# Altium schematic sheet Power.SchDoc(Power)
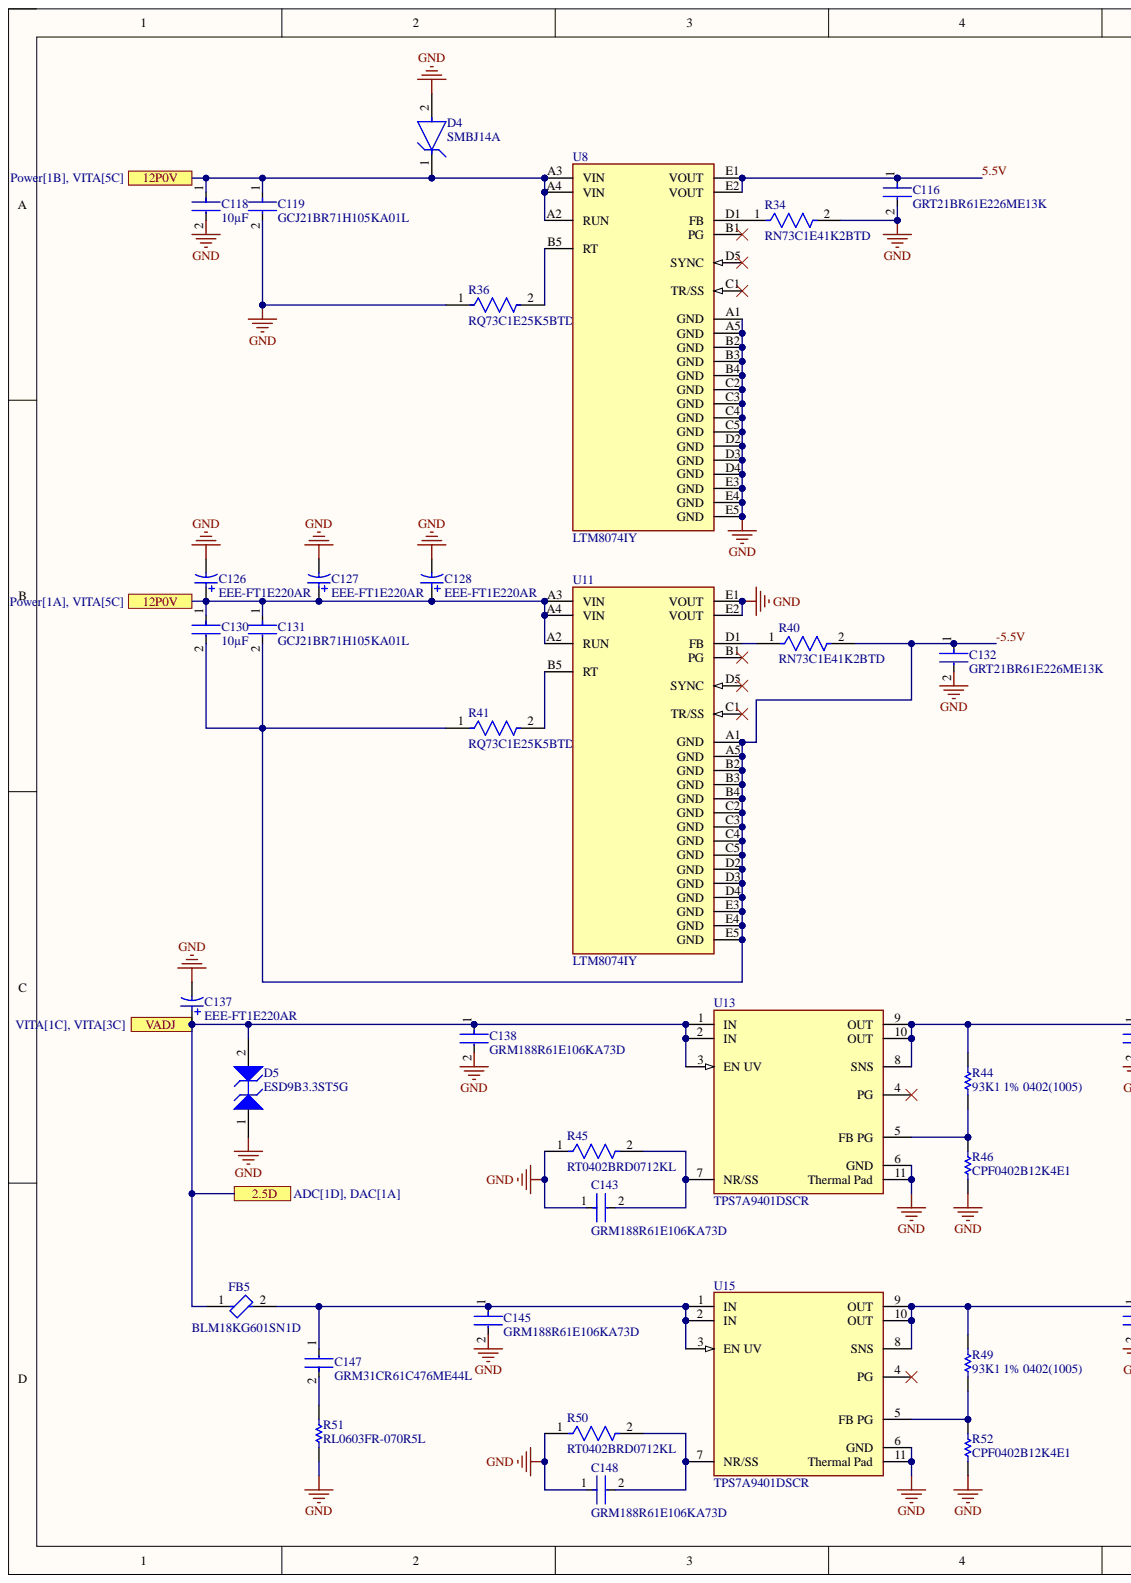
[diagram p0: Power.SchDoc, left]
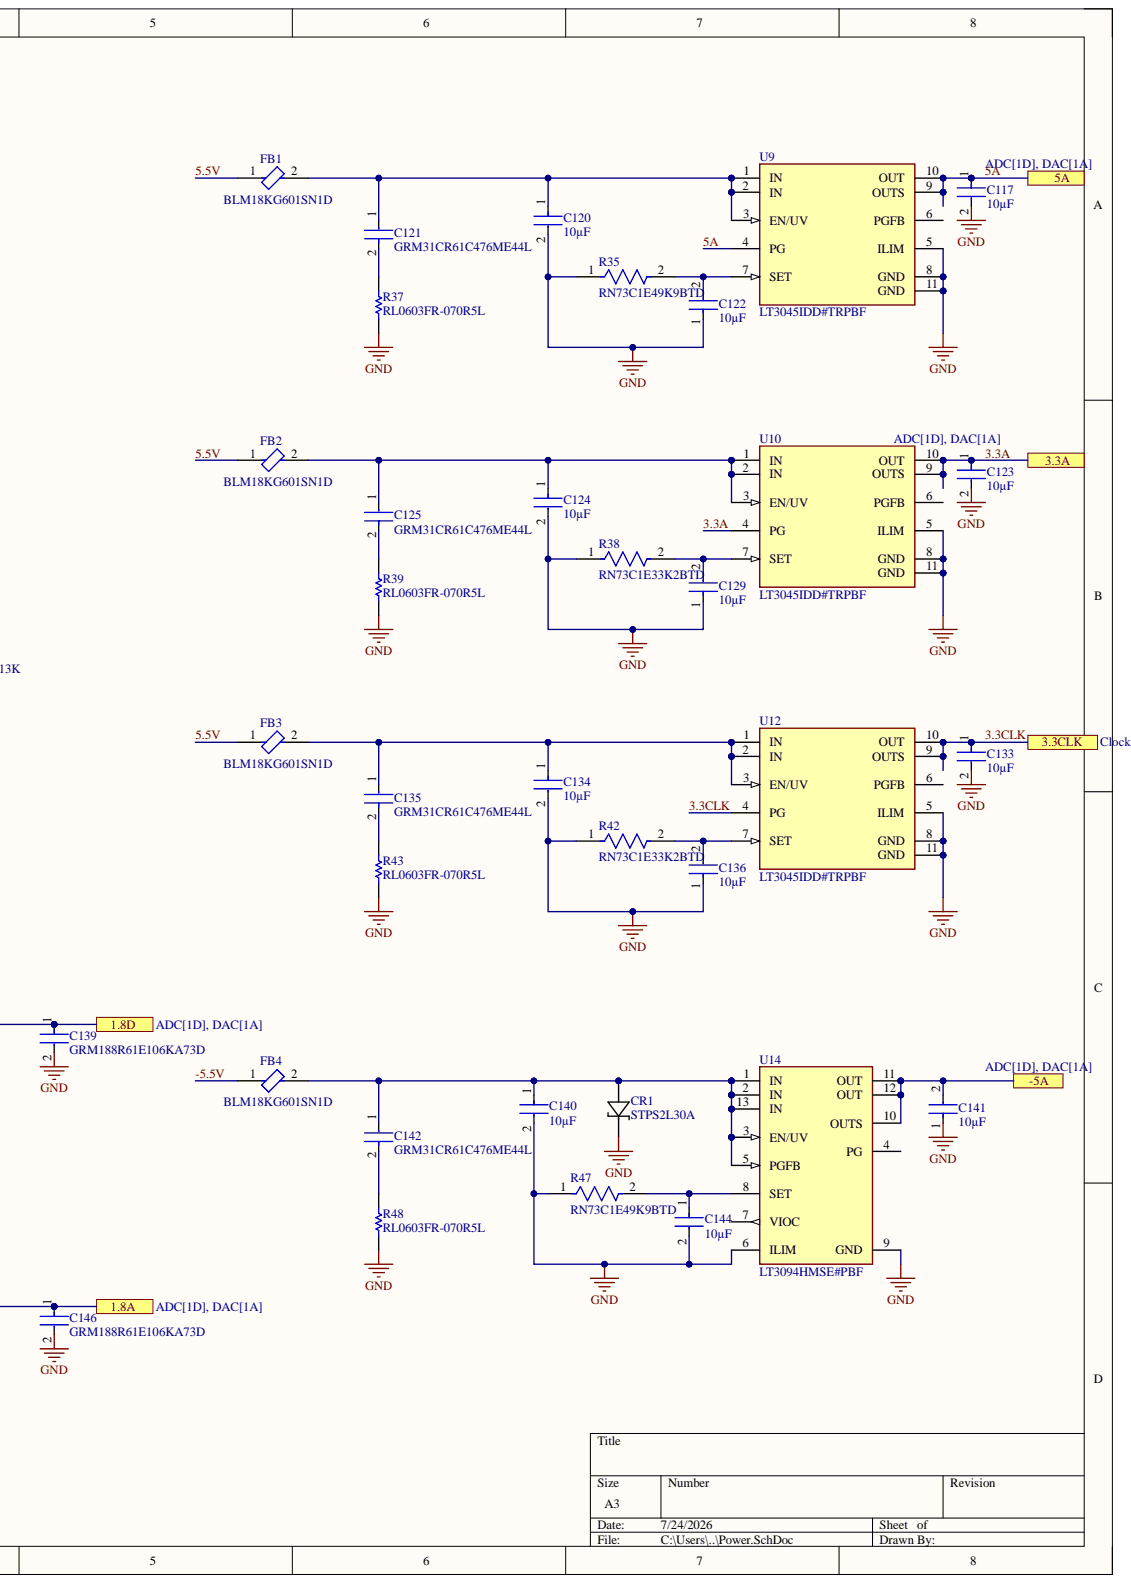
[diagram p1: Power.SchDoc, right]

## Parts
C116  GRT21BR61E226ME13K  [FP-GRT21B-0_2-IPC_C]
C117  GRM188R61E106KA73D  value 10µF  [FP-GRM188-0_2-IPC_C]
C118  GRM188R61E106KA73D  value 10µF  [FP-GRM188-0_2-IPC_C]
C119  GCJ21BR71H105KA01L  [FP-GCJ21B-0_3-IPC_C]
C120  GRM188R61E106KA73D  value 10µF  [FP-GRM188-0_2-IPC_C]
C121  GRM31CR61C476ME44L  [FP-GRM31C-0_3-e0_3_0_8-IPC_C]
C122  GRM188R61E106KA73D  value 10µF  [FP-GRM188-0_2-IPC_C]
C123  GRM188R61E106KA73D  value 10µF  [FP-GRM188-0_2-IPC_C]
C124  GRM188R61E106KA73D  value 10µF  [FP-GRM188-0_2-IPC_C]
C125  GRM31CR61C476ME44L  [FP-GRM31C-0_3-e0_3_0_8-IPC_C]
C126  EEE-FT1E220AR  [PNSC-FT-V-43x43-2_V]
C127  EEE-FT1E220AR  [PNSC-FT-V-43x43-2_V]
C128  EEE-FT1E220AR  [PNSC-FT-V-43x43-2_V]
C129  GRM188R61E106KA73D  value 10µF  [FP-GRM188-0_2-IPC_C]
C130  GRM188R61E106KA73D  value 10µF  [FP-GRM188-0_2-IPC_C]
C131  GCJ21BR71H105KA01L  [FP-GCJ21B-0_3-IPC_C]
C132  GRT21BR61E226ME13K  [FP-GRT21B-0_2-IPC_C]
C133  GRM188R61E106KA73D  value 10µF  [FP-GRM188-0_2-IPC_C]
C134  GRM188R61E106KA73D  value 10µF  [FP-GRM188-0_2-IPC_C]
C135  GRM31CR61C476ME44L  [FP-GRM31C-0_3-e0_3_0_8-IPC_C]
C136  GRM188R61E106KA73D  value 10µF  [FP-GRM188-0_2-IPC_C]
C137  EEE-FT1E220AR  [PNSC-FT-V-43x43-2_V]
C138  GRM188R61E106KA73D  [FP-GRM188-0_2-IPC_C]
C139  GRM188R61E106KA73D  [FP-GRM188-0_2-IPC_C]
C140  GRM188R61E106KA73D  value 10µF  [FP-GRM188-0_2-IPC_C]
C141  GRM188R61E106KA73D  value 10µF  [FP-GRM188-0_2-IPC_C]
C142  GRM31CR61C476ME44L  [FP-GRM31C-0_3-e0_3_0_8-IPC_C]
C143  GRM188R61E106KA73D  [FP-GRM188-0_2-IPC_C]
C144  GRM188R61E106KA73D  value 10µF  [FP-GRM188-0_2-IPC_C]
C145  GRM188R61E106KA73D  [FP-GRM188-0_2-IPC_C]
C146  GRM188R61E106KA73D  [FP-GRM188-0_2-IPC_C]
C147  GRM31CR61C476ME44L  [FP-GRM31C-0_3-e0_3_0_8-IPC_C]
C148  GRM188R61E106KA73D  [FP-GRM188-0_2-IPC_C]
CR1  STPS2L30A  [SMA_STM]
D4  SMBJ14A  [FP-SMBJ-MFG]
D5  ESD9B3.3ST5G  [ONSC-SOD-923-2-514AB-01_V]
FB1  BLM18KG601SN1D  [FP-BLM18-0_15-t0_8-MFG]
FB2  BLM18KG601SN1D  [FP-BLM18-0_15-t0_8-MFG]
FB3  BLM18KG601SN1D  [FP-BLM18-0_15-t0_8-MFG]
FB4  BLM18KG601SN1D  [FP-BLM18-0_15-t0_8-MFG]
FB5  BLM18KG601SN1D  [FP-BLM18-0_15-t0_8-MFG]
R34  RN73C1E41K2BTD  [FP-RN731E-IPC_C]
R35  RN73C1E49K9BTD  [FP-RN731E-IPC_C]
R36  RQ73C1E25K5BTD  [FP-RQ731E-IPC_C]
R37  RL0603FR-070R5L  [RESC1608X55X25LL10T15]
R38  RN73C1E33K2BTD  [FP-RN731E-IPC_C]
R39  RL0603FR-070R5L  [RESC1608X55X25LL10T15]
R40  RN73C1E41K2BTD  [FP-RN731E-IPC_C]
R41  RQ73C1E25K5BTD  [FP-RQ731E-IPC_C]
R42  RN73C1E33K2BTD  [FP-RN731E-IPC_C]
R43  RL0603FR-070R5L  [RESC1608X55X25LL10T15]
R44  93K1 1% 0402(1005)  [RESC0402(1005)_L]
R45  RT0402BRD0712KL  [FP-RT0402-IPC_C]
R46  CPF0402B12K4E1  [RESC1005X35X20LL05T10]
R47  RN73C1E49K9BTD  [FP-RN731E-IPC_C]
R48  RL0603FR-070R5L  [RESC1608X55X25LL10T15]
R49  93K1 1% 0402(1005)  [RESC0402(1005)_L]
R50  RT0402BRD0712KL  [FP-RT0402-IPC_C]
R51  RL0603FR-070R5L  [RESC1608X55X25LL10T15]
R52  CPF0402B12K4E1  [RESC1005X35X20LL05T10]
U8  LTM8074IY  [FP-BGA-25-05-08-1566-MFG]
U9  LT3045IDD#TRPBF  [FP-DD-10-05-08-1699-MFG]
U10  LT3045IDD#TRPBF  [FP-DD-10-05-08-1699-MFG]
U11  LTM8074IY  [FP-BGA-25-05-08-1566-MFG]
U12  LT3045IDD#TRPBF  [FP-DD-10-05-08-1699-MFG]
U13  TPS7A9401DSCR  [FP-DSC0010J-MFG]
U14  LT3094HMSE#PBF  [FP-MSE-12-05-08-1666-MFG]
U15  TPS7A9401DSCR  [FP-DSC0010J-MFG]

## Ports
1.8A, 1.8D, 2.5D, 3.3A, 3.3CLK, 5A, 12P0V, -5A, VADJ

## Nets
GND: C116.2 C117.2 C118.2 C119.2 C120.2 C122.1 C123.2 C124.2 C126.2 C127.2 C128.2 C129.1 C132.2 C133.2 C134.2 C136.1 C137.2 C138.2 C139.2 C140.2 C141.1 C143.1 C144.2 C145.2 C146.2 C148.1 CR1.2 D4.2 D5.1 R34.2 R35.1 R36.1 R37.1 R38.1 R39.1 R42.1 R43.1 R45.1 R46.1 R47.1 R48.1 R50.1 R51.1 R52.1 U8.A1(GND) U8.A5(GND) U8.B2(GND) U8.B3(GND) U8.B4(GND) U8.C2(GND) U8.C3(GND) U8.C4(GND) U8.C5(GND) U8.D2(GND) U8.D3(GND) U8.D4(GND) U8.E3(GND) U8.E4(GND) U8.E5(GND) U9.5(ILIM) U9.8(GND) U9.11(GND) U10.5(ILIM) U10.8(GND) U10.11(GND) U11.E1(VOUT) U11.E2(VOUT) U12.5(ILIM) U12.8(GND) U12.11(GND) U13.6(GND) U13.11(Thermal_Pad) U14.6(ILIM) U14.9(GND) U15.6(GND) U15.11(Thermal_Pad)
1.8A: C146.1 R49.2 U15.8(SNS) U15.9(OUT) U15.10(OUT) ; port 1.8A
1.8D: C139.1 R44.2 U13.8(SNS) U13.9(OUT) U13.10(OUT) ; port 1.8D
2.5D: C137.1 C138.1 D5.2 FB5.1 U13.1(IN) U13.2(IN) U13.3(EN_UV) ; port 2.5D, port VADJ
3.3A: C123.1 U10.4(PG) U10.9(OUTS) U10.10(OUT) ; port 3.3A
3.3CLK: C133.1 U12.4(PG) U12.9(OUTS) U12.10(OUT) ; port 3.3CLK
5.5V: C116.1 FB1.1 FB2.1 FB3.1 U8.E1(VOUT) U8.E2(VOUT)
5A: C117.1 U9.4(PG) U9.9(OUTS) U9.10(OUT) ; port 5A
12P0V: C118.1 C119.1 C126.1 C127.1 C128.1 C130.1 C131.1 D4.1 U8.A2(RUN) U8.A3(VIN) U8.A4(VIN) U11.A2(RUN) U11.A3(VIN) U11.A4(VIN) ; port 12P0V
-5.5V: C130.2 C131.2 C132.1 FB4.1 R40.2 R41.1 U11.A1(GND) U11.A5(GND) U11.B2(GND) U11.B3(GND) U11.B4(GND) U11.C2(GND) U11.C3(GND) U11.C4(GND) U11.C5(GND) U11.D2(GND) U11.D3(GND) U11.D4(GND) U11.E3(GND) U11.E4(GND) U11.E5(GND)
-5A: C141.2 U14.10(OUTS) U14.11(OUT) U14.12(OUT) ; port -5A
NetC120_1: C120.1 C121.1 FB1.2 U9.1(IN) U9.2(IN) U9.3(EN/UV)
NetC121_2: C121.2 R37.2
NetC122_2: C122.2 R35.2 U9.7(SET)
NetC124_1: C124.1 C125.1 FB2.2 U10.1(IN) U10.2(IN) U10.3(EN/UV)
NetC125_2: C125.2 R39.2
NetC129_2: C129.2 R38.2 U10.7(SET)
NetC134_1: C134.1 C135.1 FB3.2 U12.1(IN) U12.2(IN) U12.3(EN/UV)
NetC135_2: C135.2 R43.2
NetC136_2: C136.2 R42.2 U12.7(SET)
NetC140_1: C140.1 C142.1 CR1.1 FB4.2 U14.1(IN) U14.2(IN) U14.3(EN/UV) U14.5(PGFB) U14.13(IN)
NetC142_2: C142.2 R48.2
NetC143_2: C143.2 R45.2 U13.7(NR/SS)
NetC144_1: C144.1 R47.2 U14.8(SET)
NetC145_1: C145.1 C147.1 FB5.2 U15.1(IN) U15.2(IN) U15.3(EN_UV)
NetC147_2: C147.2 R51.2
NetC148_2: C148.2 R50.2 U15.7(NR/SS)
NetR34_1: R34.1 U8.D1(FB)
NetR36_2: R36.2 U8.B5(RT)
NetR40_1: R40.1 U11.D1(FB)
NetR41_2: R41.2 U11.B5(RT)
NetR44_1: R44.1 R46.2 U13.5(FB_PG)
NetR49_1: R49.1 R52.2 U15.5(FB_PG)

Not connected: U8 B1(PG) C1(TR/SS) D5(SYNC); U9 6(PGFB); U10 6(PGFB); U11 B1(PG) C1(TR/SS) D5(SYNC); U12 6(PGFB); U13 4(PG); U14 4(PG) 7(VIOC); U15 4(PG)

## Library data of the parts
C116 C132 = CMP-06035-023845-1: Multi-Layer Ceramic Capacitor 22uF 25V X5R ±20% 0805 Emboss T/R; Component Kind: Standard; Library Name: Altium Content Vault
C117 C118 C120 C122-C124 C129 C130 C133 C134 C136 C140 C141 C144 = CMP-001-00086-1: 25V 10uF X5R ±10% 0603 Multilayer Ceramic Capacitors MLCC - SMD/SMT ROHS; Case/Package: 0603; Component Kind: Standard; Max Operating Temperature: 85°C; Min Operating Temperature: -55°C; Mounting Technology: Surface Mount; Tolerance: 10%; Voltage Rating: 25V; Library Name: Alex Clunan
C119 C131 = CMP-06035-043290-1: Multilayer Ceramic Capacitors MLCC - SMD/SMT 0805 1uF 10%, 50VDC,  X7R 15%; Voltage Rating: 50V; Capacitance: 1uF; Case Code (Imperial): 0805; Case Code (Metric): 2012; Case/Package: 0805; Component Kind: Standard; Dielectric: X7R; Features: Soft Termination; Height: 1.25mm; Lead Free: Lead Free; Length: 0.079inch; Library Name: Altium Content Vault; Max Operating Temperature: 125°C; Min Operating Temperature: -55°C; Mount: Surface Mount; Package Quantity: 3000; Packaging: Tape and Reel; Ratings: AEC-Q200; Termination: SMD/SMT; Thickness: 0.057inch; Tolerance: 10%; Voltage Rating (DC): 50V; Weight: 0.000335oz; Width: 0.049inch
C121 C125 C135 C142 C147 = CMP-06035-011430-1: Chip Multilayer Ceramic Capacitors for General Purpose, 1206, 47uF, X5R, 15%, 20%, 16V; Capacitance: 47uF; Component Kind: Standard; Dielectric: X5R; Length: 3.2mm; Library Name: Altium Content Vault; Thickness: 1.6mm; Tolerance: 20%; Voltage Rating (DC): 16V; Width: 1.6mm
C126-C128 C137 = CMP-0744-00004-1: Aluminum Electrolytic Capacitor, 22 uF +/- 20%, 25 V, -55 to 105 degC, 2-Pin 4.3 x 4.3 x 6.1 mm SMD, RoHS, Tape and Reel; Manufacturer: Panasonic; Capacitance: 22 uF; Capacitance Tolerance: +/- 20%; Rated Voltage: 25 V; Ripple Current: 160 mA; Component Kind: Standard; ComponentLink1Description: Manufacturer URL; ComponentLink1URL: http://www.panasonic.com/industrial/electronic-components/; ComponentLink2Description: Datasheet; ComponentLink2URL: http://industrial.panasonic.com/www-data/pdf/ABA0000/ABA0000CE135.pdf; ComponentLink3Description: Package Specification; ComponentLink3URL: http://industrial.panasonic.com/www-data/pdf/ABA0000/ABA0000PE248.pdf; DatasheetVersion: Mar-14; Library Name: Altium Content Vault; Mounting Technology: Surface Mount; PackageDescription: 2-Pin SMD, Body 4.3 x 4.3 mm, Height 6.1 mm; PackageReference: FT-V-43x43-2; PackageVersion: Feb-14; Packing: Tape and Reel; PartNumber: EEE-FT1E220AR; RoHS: TRUE; Temp. Range: -55 to 105 degC
C138 C139 C143 C145 C146 C148 = CMP-06035-045268-1: Chip Multilayer Ceramic Capacitors for General Purpose, 0603, 10uF, X5R, 15%, 10%, 25V; Case/Package: 0603; Component Kind: Standard; Library Name: Altium Content Vault
CR1 = STPS2L30A: 30 V, 2 A Low Drop Power Schottky Rectifier; Manufacturer_Name: STMicroelectronics; Component Kind: Standard; Library Name: STPS2L30A.IntLib; Manufacturer_Part_Number: STPS2L30A; Copyright: Copyright (C) 2025 Ultra Librarian. All rights reserved.
D4 = CMP-15642-000001-1: TVS DIODE 14V 23.2V DO214AA; Manufacturer: Taiwan Semiconductor Corporation; Breakdown Voltage: 15.6V; Direction: Unidirectional; Max Junction Temp: +150°C; Number Of Channels: 1; Peak Pulse Current: 27A; Peak Pulse Power: 600W; Standoff Height: 0.05mm; Automotive: No; Category: Diode; Clamping Voltage: 23.2V; Component Kind: Standard; ComponentLink2Description: Datasheet; ComponentLink2URL: https://www.taiwansemi.com/products/datasheet/SMBJ%20SERIES_P1812.pdf; Device Class L1: Circuit Protection; Device Class L2: TVS Diodes; Device Class L3: unset; Digikey Description: TVS DIODE 14V 23.2V DO214AA; Height: 2.65mm; Lead Free: Yes; Library Name: Altium Content Vault; Manufacturer Part Number: SMBJ14A; Mouser Description: TVS Diodes - Transient Voltage Suppressors 14V 600W 5% Uni; Octopart: https://octopart.com/smbj14a-taiwan+semiconductor-13998009; Package: SMBJ; Reverse Standoff Voltage: 14V; RoHS: TRUE; Temperature: -55°C to +150°C; Temperature Range High: +150°C; Temperature Range Low: -55°C
D5 = CMP-1063-00120-1: Transient Voltage Suppressor, Micro-Packaged Diode for ESD Protection, 2-Pin SOD-923, Pb-Free, Tape and Reel; Manufacturer: On Semiconductor; Direction: Bidirectional; IR Max (uA): 1; V(BR) Min (V): 5; VRWM Max (V): 3.3; Component Kind: Standard; ComponentLink1Description: Manufacturer URL; ComponentLink1URL: http://www.onsemi.com/; ComponentLink2Description: Datasheet; ComponentLink2URL: http://www.onsemi.cn/pub_link/Collateral/ESD9B-D.PDF; ComponentLink3Description: Package Specification; ComponentLink3URL: http://www.onsemi.com/pub_link/Collateral/514AB.PDF; DatasheetVersion: Rev. 2; Library Name: Altium Content Vault; Mounting Technology: Surface Mount; Number of Lines: 1; PackageDescription: 2-Pin SOD, Body 0.8 x 0.6 mm; PackageReference: SOD-923-2-514AB-01; PackageVersion: Rev. C, 03/2011; Packing: Tape and Reel; PartNumber: ESD9B3.3ST5G; RoHS: Pb-Free
FB1-FB5 = CMP-0686-00581-3: Chip Ferrite Bead, 0603, 600Ω @ 100MHz, 0.15Ω, 25%, 1.3A; DC Resistance (DCR): 150mR; Impedance: 600R; Material: Steel; Number of Circuits: 1; Case Code (Imperial): 0603; Case Code (Metric): 1608; Case/Package: 0603; Component Kind: Standard; Core Material: Ferrite; Current Rating: 1.3A; DC Current: 1.3A; Depth: 0.8mm; Height: 0.8mm; Inductor Type: FERRITE BEAD; Lead Free: Lead Free; Length: 1.6mm; Library Name: Altium Content Vault; Max Current Rating: 1.3A; Max DC Current: 1.3A; Max Operating Temperature: 125°C; Military Standard: Not; Min Operating Temperature: -55°C; Number of Lines: 1; Number of Pins: 2; Package Quantity: 1; Packaging: Tape and Reel; Radiation Hardening: No; REACH SVHC: No SVHC; RoHS Compliant: Yes; Series: BLM18K; Termination: SMD/SMT; Test Frequency: 100MHz; Tolerance: 25%; Weight: 7.1E-05oz; Width: 0.8mm
R34 R40 = CMP-03211-005991-1: Res Thin Film 0402 41.2KΩ 0.1% 1/16W 10ppm/°C Molded SMD; Manufacturer: TE Connectivity; Power Rating: 0.063W; Voltage Rating: 25V; Automotive: No; Category: Res; Component Kind: Standard; ComponentLink2Description: Datasheet; ComponentLink2URL: https://www.te.com/commerce/DocumentDelivery/DDEController?Action=showdoc&DocId=Data+Sheet%7F1773270%7FP%7Fpdf%7FEnglish%7FENG_DS_1773270_P.pdf%7F1-1879132-6; Composition: Thin Film; Device Class L1: Passive Components; Device Class L2: Resistors; Device Class L3: Chip SMD Resistors; Height: 0.35mm; Ipc Land Pattern Name: RESC10050X30; Lead Free: Yes; Library Name: Altium Content Vault; Manufacturer Part Number: RN73C1E41K2BTD; Octopart: https://octopart.com/rn73c1e41k2btd-te+connectivity-76703314; octopart_url: https://octopart.com/rn73c1e41k2btd-te+connectivity-76703314; Package: RN731E; packaging: 5000 Reel; Resistance: 41.2KΩ; RoHS: TRUE; Series: RN73; Temperature: -55°C to +155°C; Temperature Coefficient: 10ppm/°C; Temperature Range High: +155°C; Temperature Range Low: -55°C; Tolerance: 0.1%; Value: 41.2KΩ
R35 R47 = CMP-03211-004568-1: Res Thin Film 0402 49.9KΩ 0.1% 1/16W 10ppm/°C Molded SMD; Manufacturer: TE Connectivity; Power Rating: 0.063W; Voltage Rating: 25V; Automotive: No; Category: Res; Component Kind: Standard; ComponentLink2Description: Datasheet; ComponentLink2URL: https://www.te.com/commerce/DocumentDelivery/DDEController?Action=showdoc&DocId=Data+Sheet%7F1773270%7FP%7Fpdf%7FEnglish%7FENG_DS_1773270_P.pdf%7F1-1879132-6; Composition: Thin Film; Device Class L1: Passive Components; Device Class L2: Resistors; Device Class L3: Chip SMD Resistors; Height: 0.35mm; Ipc Land Pattern Name: RESC10050X30; Lead Free: Yes; Library Name: Altium Content Vault; Manufacturer Part Number: RN73C1E49K9BTD; Octopart: https://octopart.com/rn73c1e49k9btd-te+connectivity-76703322; octopart_url: https://octopart.com/rn73c1e49k9btd-te+connectivity-76703322; Package: RN731E; packaging: 5000 Reel; Resistance: 49.9KΩ; RoHS: TRUE; Series: RN73; Temperature: -55°C to +155°C; Temperature Coefficient: 10ppm/°C; Temperature Range High: +155°C; Temperature Range Low: -55°C; Tolerance: 0.1%; Value: 49.9KΩ
R36 R41 = CMP-03211-003145-1: RQ73 0402 25.5KΩ 0.0625W 10ppm/°C 0.1% 50V; Manufacturer: TE; Automotive Grade: Grade 0; Automotive: Yes; Category: Res; Component Kind: Standard; ComponentLink2Description: Datasheet; ComponentLink2URL: https://www.te.com/commerce/DocumentDelivery/DDEController?Action=showdoc&DocId=Data+Sheet%7F1773270-2%7FA%7Fpdf%7FEnglish%7FENG_DS_1773270-2_A.pdf%7F4-2176362-503; Composition: Thin Film; Device Class L1: Passive Components; Device Class L2: Resistors; Device Class L3: Chip SMD Resistors; Height: 0.4mm; Ipc Land Pattern Name: RESC10050X30; Lead Free: Yes; Library Name: Altium Content Vault; Manufacturer Part Number: RQ73C1E25K5BTD; Octopart: https://octopart.com/rq73c1e25k5btd-te+connectivity-93907600; Package: RQ731E; Power Rating: 0.0625W; Resistance: 25.5KΩ; RoHS: TRUE; Series: RQ73; Temperature: -55°C to +155°C; Temperature Coefficient: 10ppm/°C; Temperature Range High: +155°C; Temperature Range Low: -55°C; Tolerance: 0.1%; Value: 25.5KΩ; Voltage Rating: 50V
R37 R39 R43 R48 R51 = CMP-2000-04335-1: Manufacturer: Yageo; Component Kind: Standard; Library Name: Altium Content Vault; Part Number: RL0603FR-070R5L
R38 R42 = CMP-03211-010041-1: Res Thin Film 0402 33.2KΩ 0.1% 1/16W 10ppm/°C Molded SMD; Manufacturer: TE Connectivity; Power Rating: 0.063W; Voltage Rating: 25V; Automotive: No; Category: Res; Component Kind: Standard; ComponentLink2Description: Datasheet; ComponentLink2URL: https://www.te.com/commerce/DocumentDelivery/DDEController?Action=showdoc&DocId=Data+Sheet%7F1773270%7FP%7Fpdf%7FEnglish%7FENG_DS_1773270_P.pdf%7F1-1879132-6; Composition: Thin Film; Device Class L1: Passive Components; Device Class L2: Resistors; Device Class L3: Chip SMD Resistors; Height: 0.35mm; Ipc Land Pattern Name: RESC10050X30; Lead Free: Yes; Library Name: Altium Content Vault; Manufacturer Part Number: RN73C1E33K2BTD; Octopart: https://octopart.com/rn73c1e33k2btd-te+connectivity-76703305; octopart_url: https://octopart.com/rn73c1e33k2btd-te+connectivity-76703305; Package: RN731E; packaging: 5000 Reel; Resistance: 33.2KΩ; RoHS: TRUE; Series: RN73; Temperature: -55°C to +155°C; Temperature Coefficient: 10ppm/°C; Temperature Range High: +155°C; Temperature Range Low: -55°C; Tolerance: 0.1%; Value: 33.2KΩ
R44 R49 = CMP-1011-00733-1: 93K1 0.063W 1% 0402 (1005 Metric)  SMD; Case-EIA: 0402; Case-Metric: 1005; Value: 93100.00 Ohm; Component Kind: Standard; Library Name: Altium Content Vault; Power: 0.063 W; Technology: SMT; Tolerance: 1 %
R45 R50 = CMP-2002-02800-2: RES 12.0K OHM 1/16W .1% SMD 0402; Manufacturer: Yageo; Power Rating: 0.063W; Resistance: 12KΩ; Temperature Coefficient: 25 ppm/°C; Tolerance: 0.001; Value: 12KΩ; Voltage Rating: 50V; Automotive: No; Category: Res; Component Kind: Standard; ComponentLink2Description: Datasheet; ComponentLink2URL: http://www.yageo.com/documents/recent/PYu-RT_1-to-0.01_RoHS_L_9.pdf; Composition: Thin Film; Device Class L1: Passive Components; Device Class L2: Resistors; Device Class L3: Chip SMD Resistors; Digikey Description: RES 12.0K OHM 1/16W .1% SMD 0402; Footprint Url: http://www.yageo.com/exep/pages/download/literatures/PYu-R_Mount_10.pdf; Height: 0.35mm; Ipc Land Pattern Name: RESC10050X30; Lead Free: Yes; Library Name: Altium Content Vault; Manufacturer Part Number: RT0402BRD0712KL; Mouser Description: Thin Film Resistors - SMD 1/16W 12K ohm .1% 25ppm; Octopart: https://octopart.com/rt0402brd0712kl-yageo-1276896; Package: RT0402; RoHS: TRUE; Series: RT; Temperature: -55°C to +155°C; Temperature Range High: +155°C; Temperature Range Low: -55°C
R46 R52 = CMP-2002-03126-1: Manufacturer: TE Connectivity / AMP; Component Kind: Standard; Library Name: Altium Content Vault; Part Number: CPF0402B12K4E1
U8 U11 = CMP-09139-000123-1: IC REG BUCK ADJ 1.2A 25BGA; Component Kind: Standard; Library Name: Altium Content Vault; RoHS Compliant: No
U9 U10 U12 = CMP-09138-000122-1: IC REG LINEAR 0V 500MA 10DFN; Case/Package: DFN; Component Kind: Standard; Library Name: Altium Content Vault
U13 U15 = CMP-04917-000380-1: 1-A, ULTRA-LOW NOISE, ULTRA-HIGH; Component Kind: Standard; Library Name: Altium Content Vault
U14 = CMP-09138-000011-1: -20V, 500MA ULTRALOW NOISE/PSRR; Manufacturer: Analog Devices; Accuracy Percentage: 1%; Dropout Voltage: 235mV; Max Junction Temp: +150°C; Max Supply Voltage: -2.3V; Nominal Supply Current: 3uA; Number Of Outputs: 1; Output Type: AdjustableProgrammable; Output Voltage: -19.5-0V; Standoff Height: 0.0508mm; Automotive: No; Category: IC; Component Kind: Standard; ComponentLink2Description: Datasheet; ComponentLink2URL: https://www.analog.com/media/en/technical-documentation/data-sheets/LT3094.pdf; Device Class L1: Integrated Circuits (ICs); Device Class L2: Power Management ICs; Device Class L3: Voltage Regulators - Linear; Digikey Description: -20V, 500MA ULTRALOW NOISE/PSRR; Height: 1.1mm; Lead Free: Yes; Library Name: Altium Content Vault; Manufacturer Part Number: LT3094HMSE#PBF; Min Supply Voltage: -20V; Octopart: https://octopart.com/lt3094hmse%23pbf-analog+devices-98057916; Output Current: 500mA; Package: MSE-12-05-08-1666; RoHS: TRUE; Temperature: -40°C to +150°C; Temperature Range High: +150°C; Temperature Range Low: -40°C
